# Altium schematic sheet 04_Gyro.SchDoc
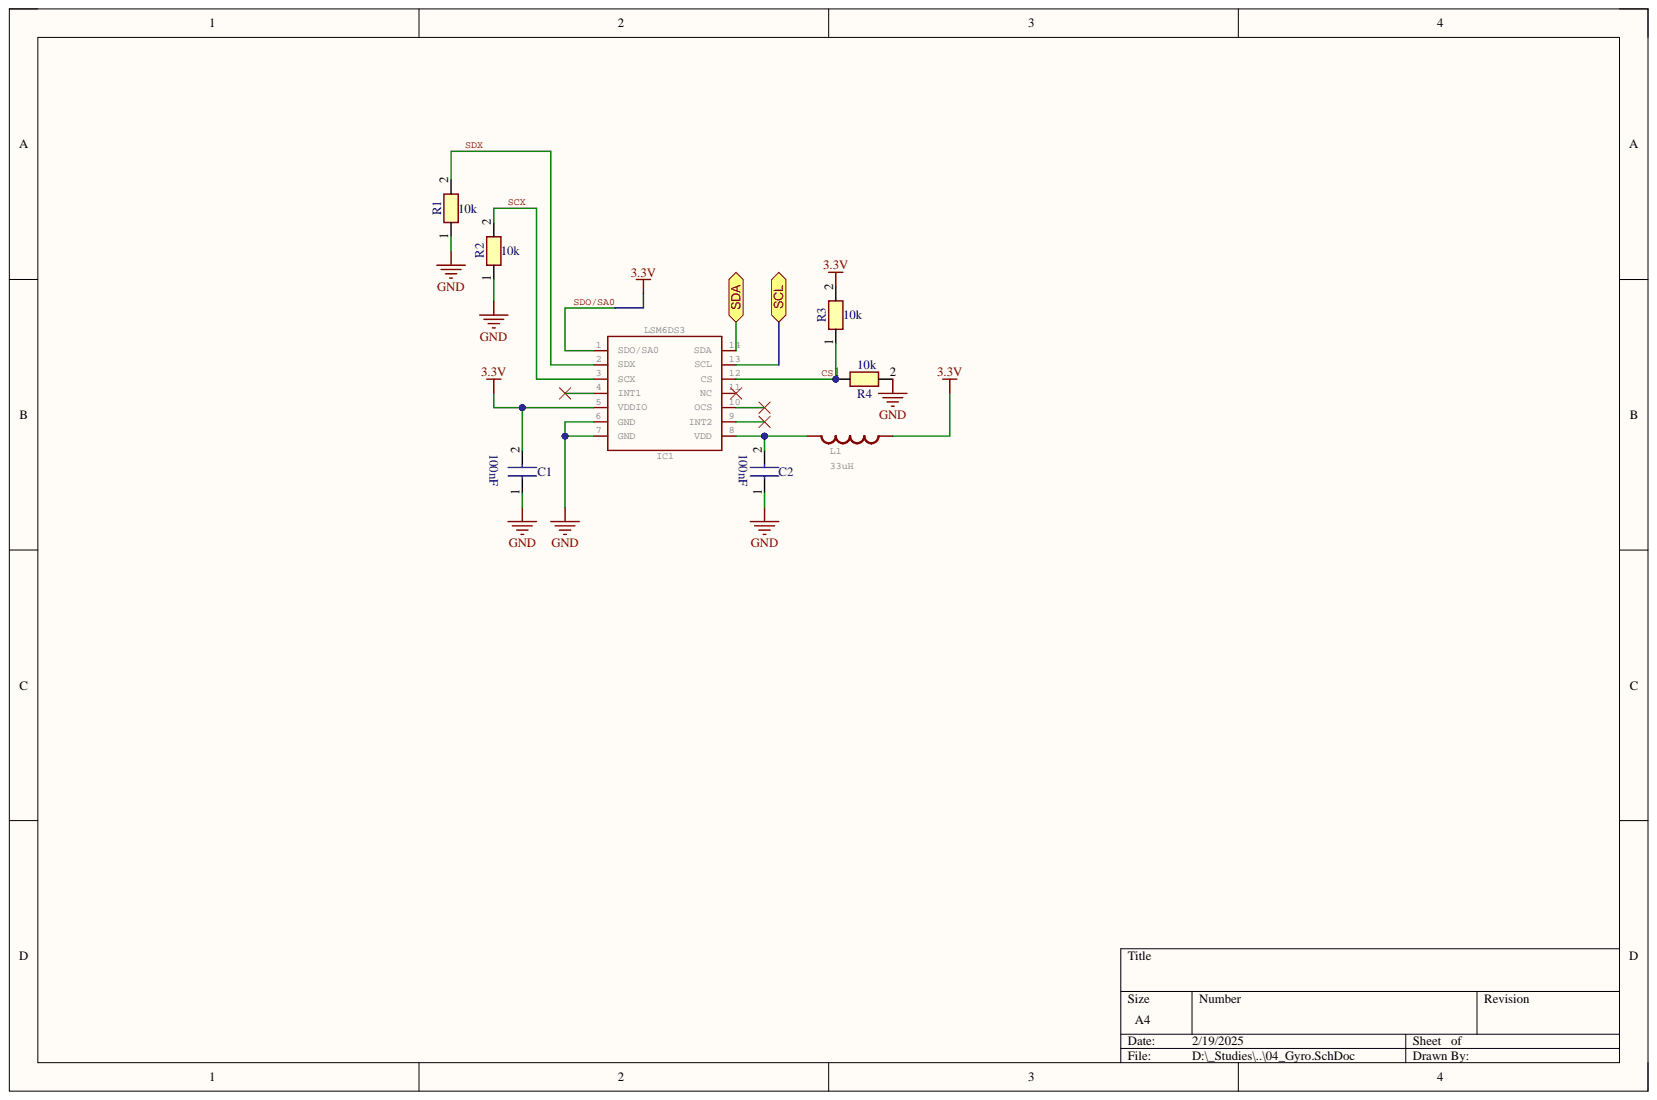
[diagram p0: 04_Gyro.SchDoc]

## Parts
C1  100nF  [CAPC2012X94N]
C2  100nF  [CAPC2012X94N]
IC1  LSM6DS3  [LSM6DS3USTR]
L1  33uH  [INDC2012X125N]
R1  10k  [RESC2012X60N]
R2  10k  [RESC2012X60N]
R3  10k  [RESC2012X60N]
R4  10k  [RESC2012X60N]

## Ports
SCL, SDA

## Nets
GND: C1.1 C2.1 IC1.6(GND) IC1.7(GND) R1.1 R2.1 R4.2
CS: IC1.12(CS) R3.1 R4.1
SCL: IC1.13(SCL) ; port SCL
SCX: IC1.3(SCX) R2.2
SDA: IC1.14(SDA) ; port SDA
SDO/SA0: C1.2 IC1.1(SDO/SA0) IC1.5(VDDIO) L1.1 R3.2
SDX: IC1.2(SDX) R1.2
NetC2_2: C2.2 IC1.8(VDD) L1.2

Not connected: IC1 4(INT1) 9(INT2) 10(OCS) 11(NC)

## Library data of the parts
C1 C2 = 08055C104KAZ2A: Capacitor; Manufacturer_Name: Kyocera AVX; Arrow Part Number: 08055C104KAZ2A; Arrow Price/Stock: https://www.arrow.com/en/products/08055c104kaz2a/avx; Component Kind: Standard; ComponentLink1Description: Datasheet; ComponentLink1URL: http://datasheets.avx.com/X7RDielectric.pdf; Datasheet Link: http://datasheets.avx.com/X7RDielectric.pdf; Height: 0.94mm; Manufacturer_Part_Number: 08055C104KAZ2A; Mouser Part Number: 581-08055C104KAZ2A; Mouser Price/Stock: https://www.mouser.co.uk/ProductDetail/KYOCERA-AVX/08055C104KAZ2A?qs=qS4bad6%2Fhx%2FmMvJL3brjow%3D%3D; Library Name: BraaiBuddyPCB_Schematic_Library.SCHLIB
IC1 = LSM6DS3DOT_INDICATION: GateName_1: G$1; PROD_ID: IC-12969; Component Kind: Standard; ComponentLink1Description: Datasheet; ComponentLink1URL: https://www.mouser.co.za/datasheet/2/389/lsm6ds3tr_c-1761429.pdf; DeviceName: DOT_INDICATION; DeviceSetName: LSM6DS3; LibraryName: LSM6DS3; SymbolName_1: LSM6DS3; Library Name: BraaiBuddyPCB_Schematic_Library.SCHLIB
L1 = INDUCTOR30OHM,1.8A: GateName_1: G$1; PROD_ID: RES-07859; Component Kind: Standard; ComponentLink1Description: Datasheet; ComponentLink1URL: https://www.mouser.co.za/datasheet/2/54/CV201210-777527.pdf; DeviceName: 30OHM,1.8A; DeviceSetName: INDUCTOR; LibraryName: SparkFun-Passives; SymbolName_1: INDUCTOR; Library Name: BraaiBuddyPCB_Schematic_Library.SCHLIB
R1-R4 = CR0805-FX-1002ELF: Resistor; Manufacturer_Name: Bourns; Arrow Part Number: CR0805-FX-1002ELF; Arrow Price/Stock: https://www.arrow.com/en/products/cr0805-fx-1002elf/bourns?region=nac; Component Kind: Standard; Datasheet Link: https://www.bourns.com/pdfs/chpreztr.pdf; Height: 0.6mm; Manufacturer_Part_Number: CR0805-FX-1002ELF; Mouser Part Number: 652-CR0805FX-1002ELF; Mouser Price/Stock: https://www.mouser.co.uk/ProductDetail/Bourns/CR0805-FX-1002ELF?qs=tknLyCMIRbPr%252BDD0s0nG9g%3D%3D; Library Name: BraaiBuddyPCB_Schematic_Library.SCHLIB
